# Altium schematic sheet Sheet1.SchDoc
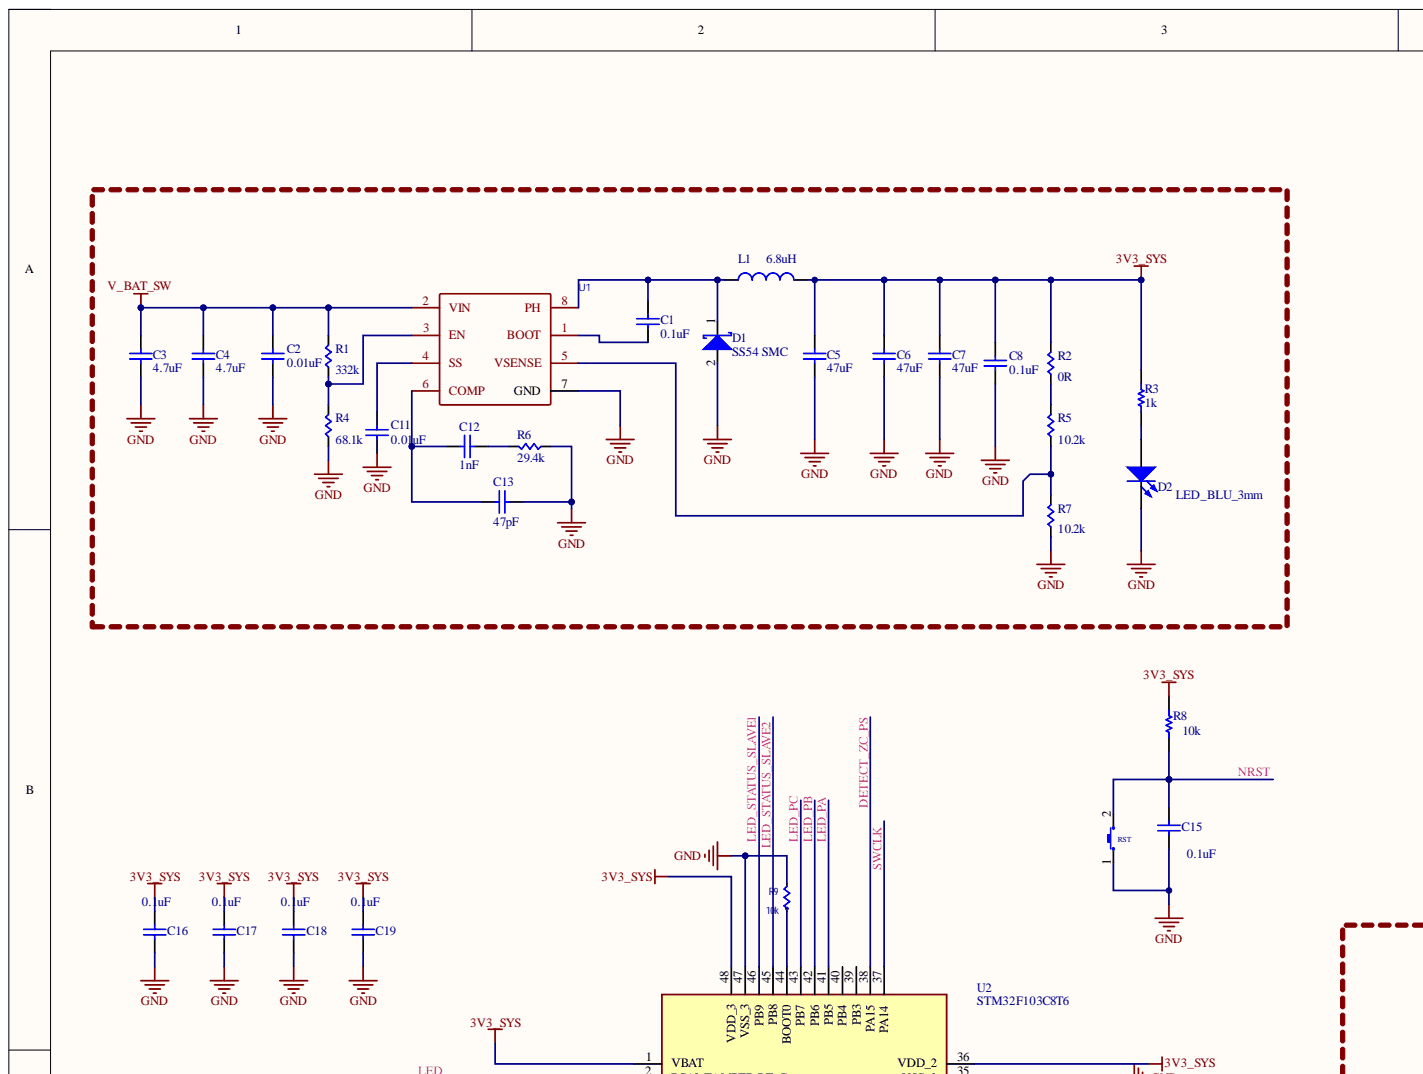
[diagram p0: Sheet1.SchDoc, top left]
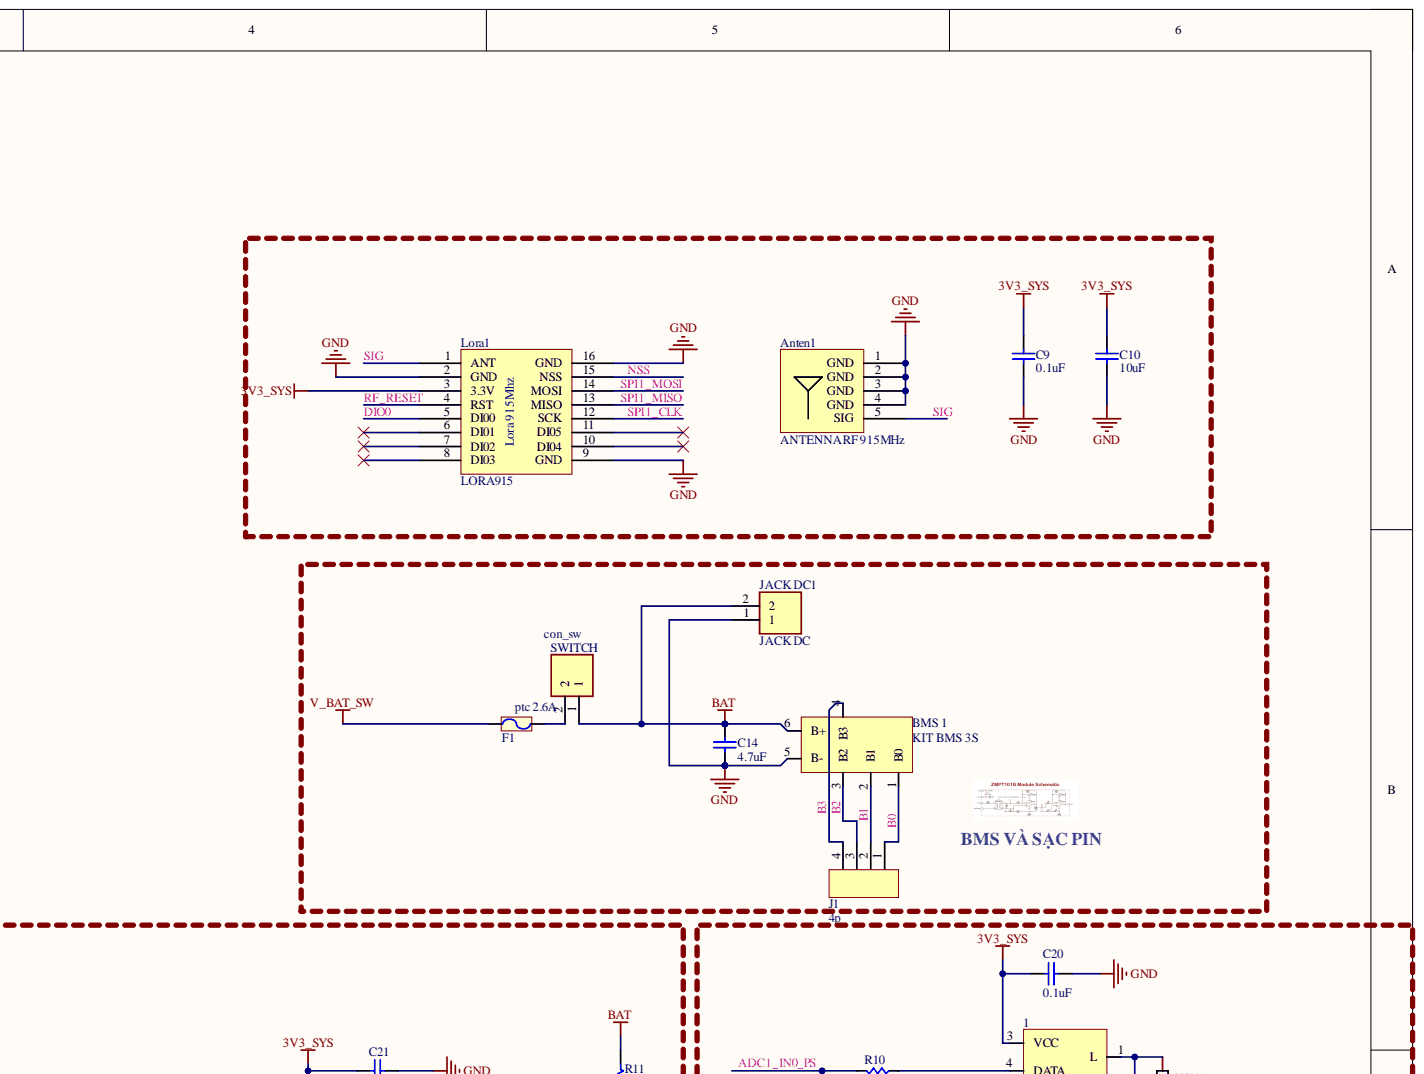
[diagram p1: Sheet1.SchDoc, top right]
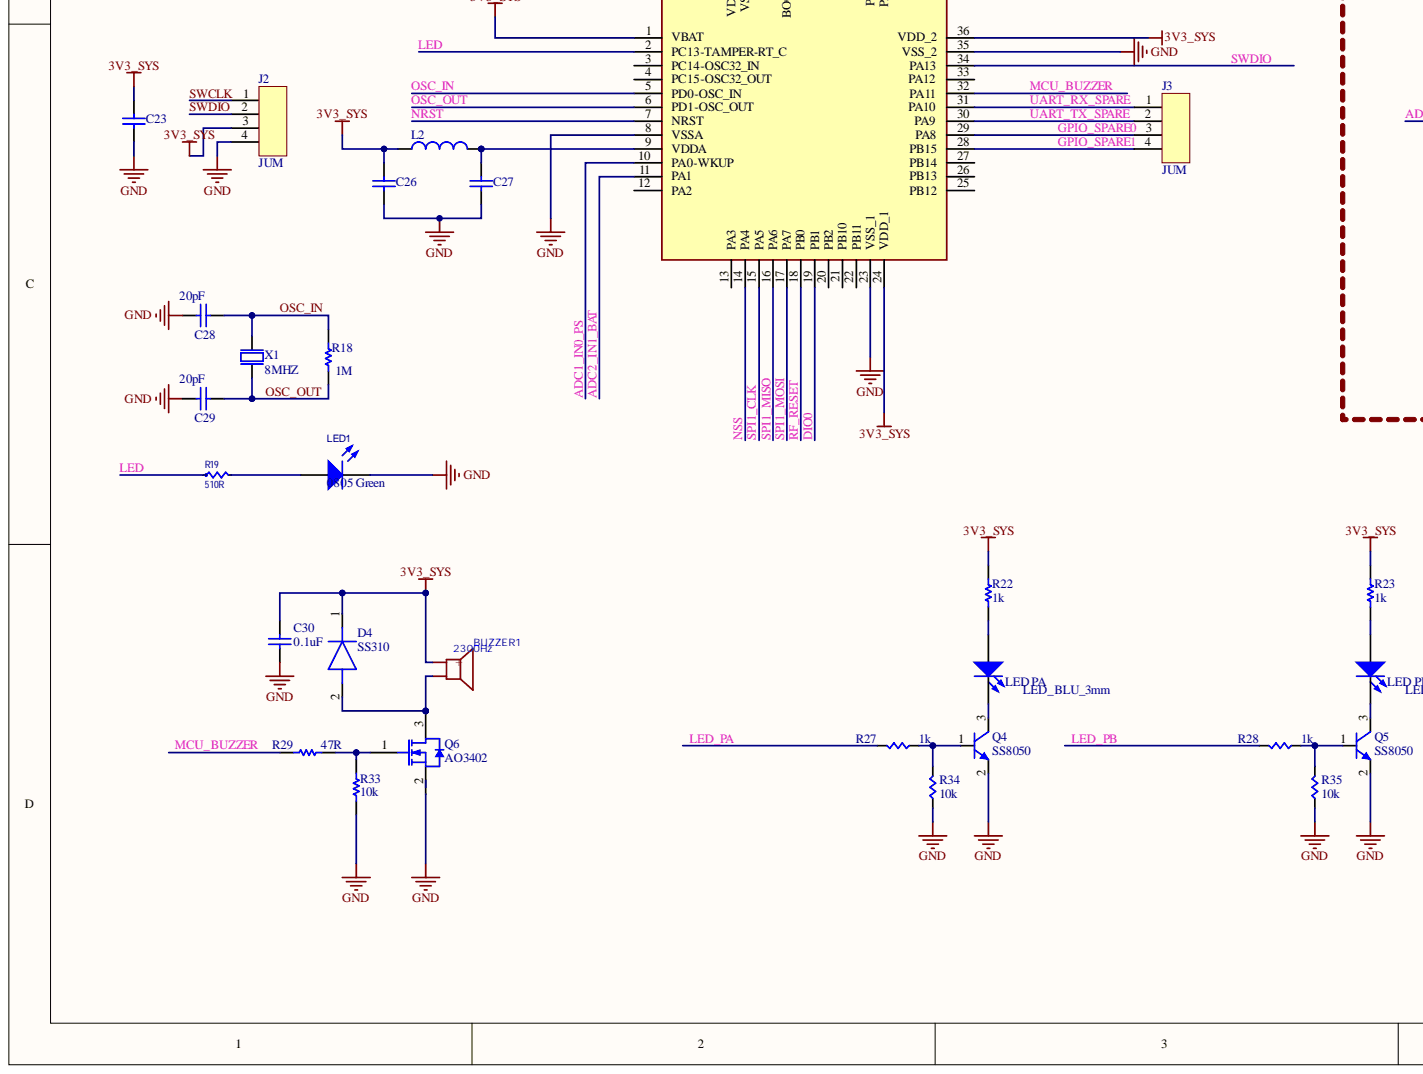
[diagram p2: Sheet1.SchDoc, bottom left]
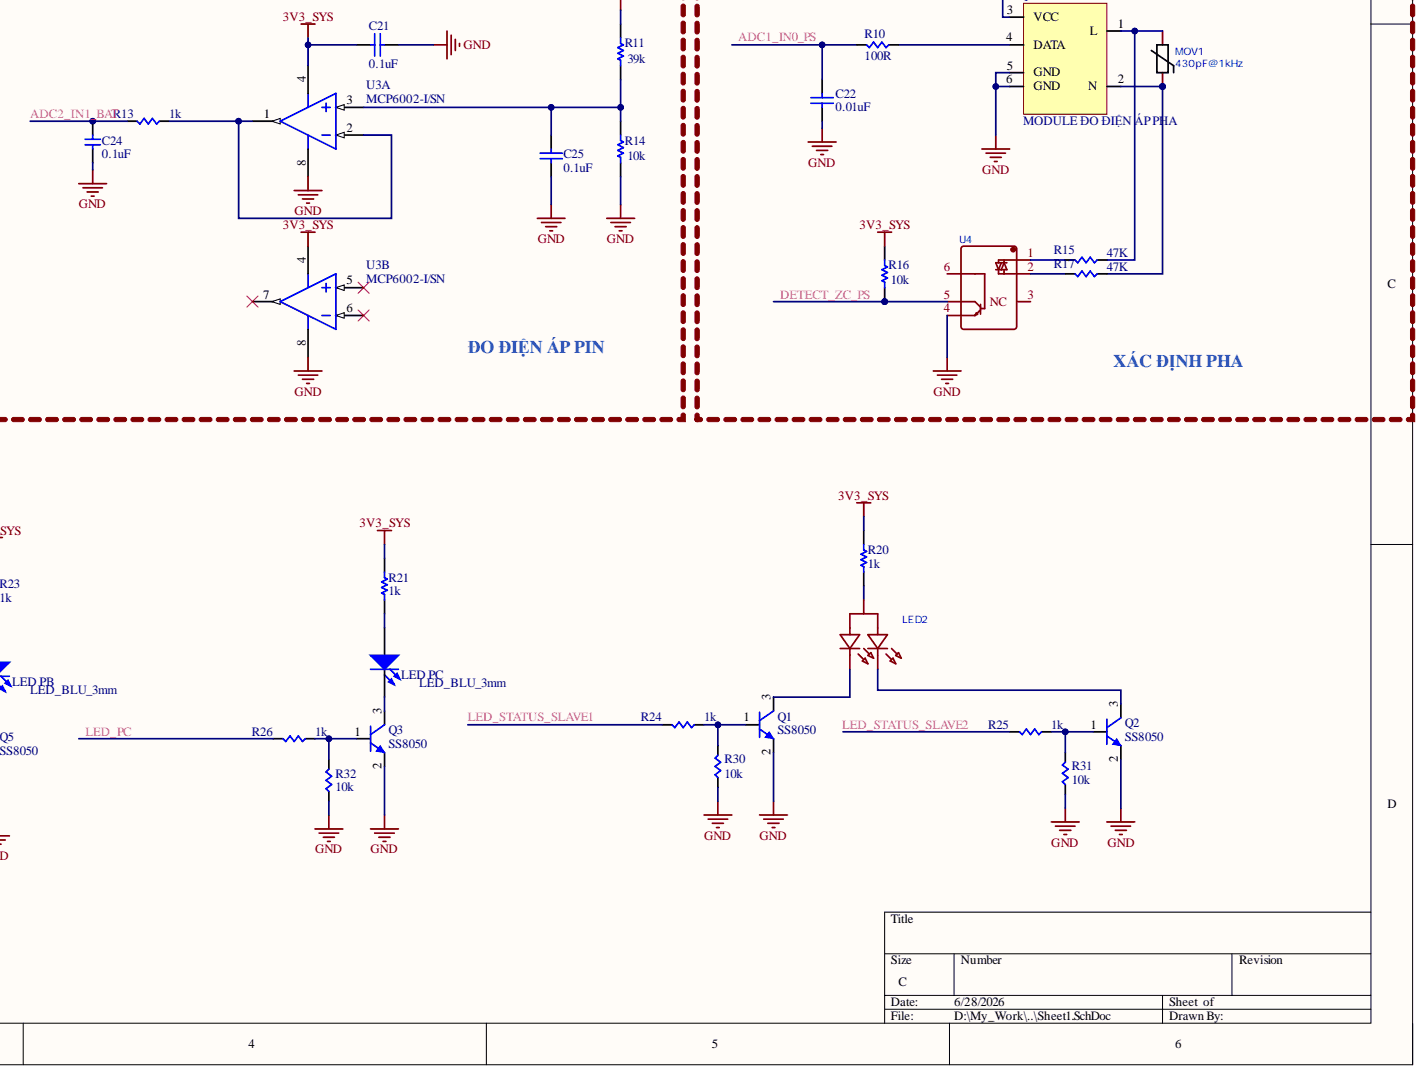
[diagram p3: Sheet1.SchDoc, bottom right]

## Parts
1  MODULE ĐO ĐIỆN ÁP PHA  [KIT_ZMPT101B]
Anten1  ANTENNA RF 915MHz  [ANTEN_SMA]
BMS_1  KIT BMS 3S  [KIT_BMS_3S_20A]
BUZZER1  2300Hz  [BUZZER 9MM]
C1  0.1uF  [CAP_0603]
C2  0.01uF  [CAP_0603]
C3  4.7uF  [CAP_1206]
C4  4.7uF  [CAP_1206]
C5  47uF  [CAP_1206]
C6  47uF  [CAP_1206]
C7  47uF  [CAP_1206]
C8  0.1uF  [CAP_0603]
C9  0.1uF  [CAP_0603]
C10  10uF  [CAP_1206]
C11  0.01uF  [CAP_0603]
C12  1nF  [CAP_0603]
C13  47pF  [CAP_0603]
C14  4.7uF  [CAP_1206]
C15  0.1uF  [CAP_0603]
C16  0.1uF  [CAP_0603]
C17  0.1uF  [CAP_0603]
C18  0.1uF  [CAP_0603]
C19  0.1uF  [CAP_0603]
C20  0.1uF  [CAP_0603]
C21  0.1uF  [CAP_0603]
C22  0.01uF  [CAP_0603]
C23  0.1uF  [CAP_0603]  (value not drawn)
C24  0.1uF  [CAP_0603]
C25  0.1uF  [CAP_0603]
C26  10uF  [CAP_1206]  (value not drawn)
C27  10uF  [CAP_1206]  (value not drawn)
C28  20pF  [CAP_0603]
C29  20pF  [CAP_0603]
C30  0.1uF  [CAP_0603]
D1  SS54 SMC  [SMB]
D2  LED_BLU_3mm  [LED_3mm]
D4  SS310  [SMA (DO-214AC)]
F1  ptc 2.6A  [FUSE_1812]
J1  4p  [CON_1x4p_2.54mm_F_STR]
J2  JUM  [CON_1x4p_2.54_M_straight]
J3  JUM  [CON_1x4p_2.54_M_straight]
JACK_DC1  JACK DC  [CON_1x2P_2.54mm_F_STR_XH]
L1  6.8uH  [IND_6.6x7.3mm]
L2  IND 2.2UH  [RES_0805]  (value not drawn)
LED1  0805 Green  Package: 0805  [LED_0603]
LED2  (no value)  [LED_3P_D3mm]
LED_PA  LED_BLU_3mm  [LED_3mm]
LED_PB  LED_BLU_3mm  [LED_3mm]
LED_PC  LED_BLU_3mm  [LED_3mm]
Lora1  LORA915  [LORA_RA-01H]
MOV1  430pF@1kHz  [MOV_7.5mm]
Q1  SS8050  [SOT-23-3]
Q2  SS8050  [SOT-23-3]
Q3  SS8050  [SOT-23-3]
Q4  SS8050  [SOT-23-3]
Q5  SS8050  [SOT-23-3]
Q6  AO3402  [SOT-23-3]
R1  332k  [RES_0603]
R2  0R  [RES_0603]
R3  1k  [RES_0805]
R4  68.1k  [RES_0603]
R5  10.2k  [RES_0603]
R6  29.4k  [RES_0603]
R7  10.2k  [RES_0603]
R8  10k  [RES_0603]
R9  10k  [RES_0603]
R10  100R  [RES_0603]
R11  39k  [RES_0603]
R13  1k  [RES_0603]
R14  10k  [RES_0603]
R15  47K  [RES_DIP_D4.8xL13mm]
R16  10k  [RES_0603]
R17  47K  [RES_DIP_D4.8xL13mm]
R18  1M  [RES_0603]
R19  510R  [RES_0603]
R20  1k  [RES_0805]
R21  1k  [RES_0805]
R22  1k  [RES_0805]
R23  1k  [RES_0805]
R24  1k  [RES_0603]
R25  1k  [RES_0603]
R26  1k  [RES_0603]
R27  1k  [RES_0603]
R28  1k  [RES_0603]
R29  47R  [RES_0603]
R30  10k  [RES_0603]
R31  10k  [RES_0603]
R32  10k  [RES_0603]
R33  10k  [RES_0603]
R34  10k  [RES_0603]
R35  10k  [RES_0603]
RST  button  [BUTTON_SMD_3625]  (value not drawn)
SWITCH  con_sw  [CON_1x2P_2.54mm_F_STR_XH]
U1  (no value)  [SOIC-8]
U2  STM32F103C8T6  [LQFP-48]
U3  MCP6002-I/SN  [SOIC-SN8_M]  (parts A,B)
U4  (no value)  [PDIP-6]
X1  8MHZ  [Crystal_5032]

## Nets
3V3_SYS: 1.3(VCC) BUZZER1.1 C5.2 C6.2 C7.2 C8.1 C9.2 C10.2 C16.2 C17.2 C18.2 C19.2 C20.2 C21.2 C23.2 C26.2 C30.2 D4.1 J2.3 L1.2 L2.1 Lora1.3(3.3V) R2.2 R3.1 R8.2 R16.1 R20.1 R21.1 R22.1 R23.1 U2.1(VBAT) U2.24(VDD_1) U2.36(VDD_2) U2.48(VDD_3) U3.4
BAT: BMS_1.6(B+) C14.2 JACK_DC1.2 R11.1 SWITCH.1
GND: 1.5(GND) 1.6(GND) Anten1.1(GND) Anten1.2(GND) Anten1.3(GND) Anten1.4(GND) BMS_1.5(B-) C2.2 C3.1 C4.1 C5.1 C6.1 C7.1 C8.2 C9.1 C10.1 C11.2 C13.2 C14.1 C15.1 C16.1 C17.1 C18.1 C19.1 C20.1 C21.1 C22.2 C23.1 C24.2 C25.1 C26.1 C27.1 C28.1 C29.1 C30.1 D1.2 D2.1 J2.4 JACK_DC1.1 LED1.2 Lora1.2(GND) Lora1.9(GND) Lora1.16(GND) Q1.2 Q2.2 Q3.2 Q4.2 Q5.2 Q6.2 R4.1 R6.1 R7.1 R9.2 R14.2 R30.1 R31.1 R32.1 R33.1 R34.1 R35.1 RST.1 U1.7(GND) U2.8(VSSA) U2.23(VSS_1) U2.35(VSS_2) U2.47(VSS_3) U3.8 U4.4
V_BAT_SW: C2.1 C3.2 C4.2 F1.1 R1.2 U1.2(VIN)
ADC1_IN0_PS: C22.1 R10.2 U2.10(PA0-WKUP)
ADC2_IN1_BAT: C24.1 R13.2 U2.11(PA1)
B0: BMS_1.1(B0) J1.1
B1: BMS_1.2(B1) J1.2
B2: BMS_1.3(B2) J1.3
B3: BMS_1.4(B3) J1.4
DETECT_ZC_PS: R16.2 U2.38(PA15) U4.5
DIO0: Lora1.5(DI00) U2.19(PB1)
GPIO_SPARE0: J3.3 U2.29(PA8)
GPIO_SPARE1: J3.4 U2.28(PB15)
LED: R19.1 U2.2(PC13-TAMPER-RT_C)
LED_PA: R27.2 U2.41(PB5)
LED_PB: R28.2 U2.42(PB6)
LED_PC: R26.2 U2.43(PB7)
LED_STATUS_SLAVE1: R24.2 U2.46(PB9)
LED_STATUS_SLAVE2: R25.2 U2.45(PB8)
MCU_BUZZER: R29.2 U2.32(PA11)
NRST: C15.2 R8.1 RST.2 U2.7(NRST)
NSS: Lora1.15(NSS) U2.14(PA4)
OSC_IN: C28.2 R18.1 U2.5(PD0-OSC_IN) X1.1
OSC_OUT: C29.2 R18.2 U2.6(PD1-OSC_OUT) X1.2
RF_RESET: Lora1.4(RST) U2.18(PB0)
SIG: Anten1.5(SIG) Lora1.1(ANT)
SPI1_CLK: Lora1.12(SCK) U2.15(PA5)
SPI1_MISO: Lora1.13(MISO) U2.16(PA6)
SPI1_MOSI: Lora1.14(MOSI) U2.17(PA7)
SWCLK: J2.1 U2.37(PA14)
SWDIO: J2.2 U2.34(PA13)
UART_RX_SPARE: J3.1 U2.31(PA10)
UART_TX_SPARE: J3.2 U2.30(PA9)
Net1_1: 1.1(L) MOV1.2 R15.1
Net1_2: 1.2(N) MOV1.1 R17.1
Net1_4: 1.4(DATA) R10.1
NetBUZZER1_2: BUZZER1.2 D4.2 Q6.3
NetC1_1: C1.1 U1.1(BOOT)
NetC1_2: C1.2 D1.1 L1.1 U1.8(PH)
NetC11_1: C11.1 U1.4(SS)
NetC12_1: C12.1 C13.1 U1.6(COMP)
NetC12_2: C12.2 R6.2
NetC25_2: C25.2 R11.2 R14.1 U3.3
NetC27_2: C27.2 L2.2 U2.9(VDDA)
NetD2_2: D2.2 R3.2
NetF1_2: F1.2 SWITCH.2
NetLED1_1: LED1.1 R19.2
NetLED2_1: LED2.1 Q2.3
NetLED2_2: LED2.2 R20.2
NetLED2_3: LED2.3 Q1.3
NetLED PA_1: LED_PA.1 Q4.3
NetLED PA_2: LED_PA.2 R22.2
NetLED PB_1: LED_PB.1 Q5.3
NetLED PB_2: LED_PB.2 R23.2
NetLED PC_1: LED_PC.1 Q3.3
NetLED PC_2: LED_PC.2 R21.2
NetQ1_1: Q1.1 R24.1 R30.2
NetQ2_1: Q2.1 R25.1 R31.2
NetQ3_1: Q3.1 R26.1 R32.2
NetQ4_1: Q4.1 R27.1 R34.2
NetQ5_1: Q5.1 R28.1 R35.2
NetQ6_1: Q6.1 R29.1 R33.2
NetR1_1: R1.1 R4.2 U1.3(EN)
NetR2_1: R2.1 R5.2
NetR5_1: R5.1 R7.2 U1.5(VSENSE)
NetR9_1: R9.1 U2.44(BOOT0)
NetR13_1: R13.1 U3.1 U3.2
NetR15_2: R15.2 U4.1
NetR17_2: R17.2 U4.2

Not connected: Lora1 6(DI01) 7(DI02) 8(DI03) 10(DI04) 11(DI05); U2 3(PC14-OSC32_IN) 4(PC15-OSC32_OUT) 12(PA2) 13(PA3) 20(PB2) 21(PB10) 22(PB11) 25(PB12) 26(PB13) 27(PB14) 33(PA12) 39(PB3) 40(PB4); U3 5 6 7; U4 3(NC) 6

## Library data of the parts
1 = MODULE?: Component Kind: Standard; Library Name: Schlib1.SchLib
Anten1 = ANTENNA RF 915MHz: https://linhkienchatluong.vn/urlde-anten/de-anten-sma-5-chan-cai-cong-sma-kwe-dai-17mm_sp1918_ct14413.aspx; Manufacturer 1: BAT WIRELESS; Mfr. Part # 1: BWSMA-KWE-Z001; In Process: Yes; Process Type: DIP; VTP Description: CONN RP-SMA JACK R/A 50 OHM PCB; Category: SMA Antenna; Component Kind: Standard; Manufacturer 2: Lian Xin Technology; Mfr. Part # 2: SMA-KWE; NI Mark: Yes; Package: Plugin; Packaging: Bag; Supplier 1: LCSC; Supplier 2: LCSC; Library Name: AP AGV.IntLib
BUZZER1 = TMB12A03: https://linhkienchatluong.vn/urlbuzzer---coi-chip---coi-bao/coi-chip-3v-tmb12a03-12x95mm_sp798_ct8395.aspx; Component Kind: Standard
C1 = Cap-NP-100: 100nF ±10% 50V X7R 0805 Multilayer Ceramic Capacitors MLCC - SMD/SMT RoHS; Manufacturer: YAGEO; Mfr. Part #: CC0805KRX7R9BB104; NI Mark: Yes; Process Type: SMT; Component Kind: Standard; LCSC Part #: C49678; Library Name: Full.SchLib; Package: 0805; Packaging: Tape and Reel; Supplier: LCSC
C2 C5-C8 C11-C13 C22 = Cap-NP-100: Manufacturer: YAGEO; Mfr. Part #: CC0805KRX7R9BB104; NI Mark: Yes; Process Type: SMT; Component Kind: Standard; LCSC Part #: C49678; Library Name: Full.SchLib; Package: 0805; Packaging: Tape and Reel; Supplier: LCSC
C3 C4 C14 = Cap_0.47uF: 50V 4.7uF X5R ±10% 0805 Multilayer Ceramic Capacitors MLCC - SMD/SMT ROHS; Manufacturer: YAGEO; Mfr. Part #: CC0805KKX5R9BB475; NI Mark: Yes; Process Type: SMT; Component Kind: Standard; LCSC Part #: C2897302; Library Name: LIB_SCH_BOOST.schLib; Package: 0805; Packaging: Tape and Reel; Supplier: LCSC
C9 C20 C21 C25 = Cap-NP-100_2: Manufacturer 1: YAGEO; Mfr. Part # 1: CC0805KRX7R9BB104; In Process: Yes; Process Type: SMT; VTP Description: CAP CER 0.1UF 50V X7R 0805; Category: Cap Cereramic; Component Kind: Standard; Manufacturer 2: FH (Guangdong Fenghua Advanced Tech); Mfr. Part # 2: 0805B104K500NT; NI Mark: Yes; Package: 0805; Packaging: Tape and Reel; Supplier 1: LCSC; Supplier 2: LCSC; Value: 0.1uF; Library Name: center board V1.IntLib
C10 = Cap-NP-100_2: Manufacturer 1: FH (Guangdong Fenghua Advanced Tech); Mfr. Part # 1: 0805X106K500NT; In Process: Yes; Process Type: SMT; VTP Description: CAP CER 10UF 50V X5R 0805; Category: Cap Cereramic; Component Kind: Standard; Manufacturer 2: Samsung Electro-Mechanics; Mfr. Part # 2: CL21A106KBYQNNE; NI Mark: Yes; Package: 0805; Packaging: Tape and Reel; Supplier 1: LCSC; Supplier 2: LCSC; Value: 10uF; Library Name: center board V1.IntLib
C15-C19 C23 = Cap-104: 100nF ±10% 50V X7R 0805 Multilayer Ceramic Capacitors MLCC - SMD/SMT RoHS; Manufacturer 1: YAGEO; Mfr. Part # 1: CC0805KRX7R9BB104; NI Mark: Yes; Process Type: SM; VTP-Description: 50V 100nF X7R ±10% 0805 Multilayer Ceramic Capacitors MLCC - SMD/SMT ROHS; Component Kind: Standard; Library Name: PowerSupply Robot V1.SchLib; Manufacturer 2: FH (Guangdong Fenghua Advanced Tech); Mfr. Part # 2: 0805B104K500NT; Package: 0805; Packaging: Tape & Reel; Supplier 1: LCSC; Supplier 2: LCSC
C24 = GRM21BR61A226ME51L: 100V 100nF X7R ±10% 0603 Ceramic Capacitors RoHS; Manufacturer: YAGEO; Mfr. Part #: CC0603KRX7R0BB104; In Process: Yes; Process Type: SMT; Component Kind: Standard; Database Table Name: Capacitor; DigiKey Part Number: 311-1523-2-ND; LCSC Part #: C113803; Library Name: Component Library.DbLib; Manufacturer 1: YAGEO; Mfr. Part # 1: CC0603KRX7R0BB104; Package: 0603; Packaging: Tape and Reel; Supplier: LCSC; Value: 0.1uF; VIND_Description: 100V 100nF X7R ±10% 0603 Ceramic Capacitors RoHS
C26 C27 = Cap-10uF: 10uF ±10% 35V X5R 0805 Multilayer Ceramic Capacitors MLCC - SMD/SMT RoHS; Manufacturer 1: YAGEO; Mfr. Part # 1: CC0805KKX5R8BB106; NI Mark: Yes; Process Type: SM; VTP-Description: 25V 10uF X5R ±10% 0805 Multilayer Ceramic Capacitors MLCC - SMD/SMT ROHS; Component Kind: Standard; Library Name: PowerSupply Robot V1.SchLib; Manufacturer 2: FH (Guangdong Fenghua Advanced Tech); Mfr. Part # 2: 0805X106K250NT; Package: 0805; Packaging: Tape & Reel; Supplier 1: LCSC; Supplier 2: LCSC
C28 C29 = Cap-NP-100: 20pF ±10% 50V X7R 0805 Multilayer Ceramic Capacitors MLCC - SMD/SMT RoHS; Manufacturer 1: YAGEO; Mfr. Part # 1: CC0805JRNPO9BN200; NI Mark: Yes; Process Type: SM; VTP-Description: 50V 20pF NP0 ±5% 0805 Multilayer Ceramic Capacitors MLCC - SMD/SMT ROHS; Component Kind: Standard; Library Name: Full.SchLib; Manufacturer 2: FH (Guangdong Fenghua Advanced Tech); Mfr. Part # 2: 0805CG200J500NT; Package: 0805; Packaging: Tape & Reel; Supplier 1: LCSC; Supplier 2: LCSC
C30 = Cap-NP-100: 100V 100nF X7R ±10% 0603 Ceramic Capacitors RoHS; Manufacturer: YAGEO; Mfr. Part #: CC0603KRX7R0BB104; In Process: Yes; Process Type: SMT; Component Kind: Standard; DigiKey Part Number: 311-1523-2-ND; LCSC Part #: C113803; Library Name: Full.SchLib; Manufacturer 1: YAGEO; Mfr. Part # 1: CC0603KRX7R0BB104; Package: 0603; Packaging: Tape and Reel; Supplier: LCSC; Supplier 1: Digikey; Value: 0.1uF; VIND_Description: 100V 100nF X7R ±10% 0603 Ceramic Capacitors RoHS
D1 = diode SS14_1: https://www.linhkienchatluong.vn/urldiode-schottky/1n5824-ss54-smc-diode-schottky-5a-40v-chinh-hang-mic_sp571_ct1265.aspx; Component Kind: Standard; Library Name: PowerSupply Robot V1.SchLib
D2 LED_PA LED_PB LED_PC = LED_RED_SMD_0805: https://linhkienchatluong.vn/urlled-don-3mm---f3/led-3mm-xanh-duong-chan-dai-27mm-10-chiec_sp1975_ct1886.aspx; Component Kind: Standard; Library Name: center board V1.IntLib
D4 = 1N4148W-7-F: https://linhkienchatluong.vn/urldiode-schottky/sr3100-ss310-sma-diode-schottky-3a-100v-chinh-hang-mic-10-chiec_sp571_ct11113.aspx; Manufacturer: DIODES; In Process: Yes; Process Type: SMT; VIND_Description: 2A 4ns 100V Independent 1.25V@150mA 300mA SOD-123 Single Diodes RoHS; Component Kind: Standard; LCSC Part #: C83528; Library Name: SamacSys.SchLib; Mfr. Part #: 1N4148W-7-F; Package: SOD-123; Packaging: Tape and Reel; Supplier: LCSC; Value: 1N4148W-7-F
F1 = Fuse: https://linhkienchatluong.vn/urlcau-chi-tu-phuc-hoi-ptc/cau-chi-tu-phuc-hoi-1812-26a-6v-smd-chinh-hang-jdtfuse_sp845_ct1259.aspx; Manufacturer: Littelfuse; Mfr. Part #: 0466005.NRHF; NI Mark: Yes; Process Type: SMT; Component Kind: Standard; LCSC Part #: C57525; Library Name: ServoDrive.IntLib; Package: 1206; Packaging: Tape and Reel; Supplier: LCSC
J1 = CON HDR 4 PIN 1X4 2.5MM XHB-4A: https://linhkienchatluong.vn/urlheader-xh254-chan-duc-thang/header-duc-4p-xh254-4p-chan-thang-10-chiec_sp1781_ct1713.aspx; Manufacturer 1: HCTL; Mfr. Part # 1: HC-XHB-4AW; NI Mark: Yes; Process Type: MA; VTP-Description: 1x4P 4P 1 Tin 2.5mm 4 -25℃~+85℃ Brass 3A Right-Angle Push-Pull,P=2.5mm Wire To Board Connector ROHS; Component Kind: Standard; Library Name: PowerSupply Robot V1.SchLib; Manufacturer 2: BOOMELE(Boom Precision Elec); Mfr. Part # 2: XH-4AW; Package: Push-Pull,P=2.5mm; Packaging: Bag-packed; Supplier 1: LCSC; Supplier 2: LCSC
J2 J3 = CON HDR 4 PIN 1X4 2.5MM XHB-4A: 1x4P 4P XH 1 2.5mm 4 Phosphor bronze Right-Angle Push-Pull,P=2.5mm Wire To Board Connector ROHS; Manufacturer 1: HCTL; Mfr. Part # 1: HC-XHB-4AW; NI Mark: Yes; Process Type: MA; VTP-Description: 1x4P 4P 1 Tin 2.5mm 4 -25℃~+85℃ Brass 3A Right-Angle Push-Pull,P=2.5mm Wire To Board Connector ROHS; Component Kind: Standard; Library Name: PowerSupply Robot V1.SchLib; Manufacturer 2: BOOMELE(Boom Precision Elec); Mfr. Part # 2: XH-4AW; Package: Push-Pull,P=2.5mm; Packaging: Bag-packed; Supplier 1: LCSC; Supplier 2: LCSC
JACK_DC1 SWITCH = Header 2P Plug 90 5.08: https://linhkienchatluong.vn/urlheader-xh254-chan-duc-thang/header-duc-2p-xh254-2p-chan-thang-10c_sp1781_ct1711.aspx; Component Kind: Standard; Library Name: Integrated_Library_EVT.IntLib
L1 = inductor 2.2uF: https://linhkienchatluong.vn/urlcuon-cam-dan-cd104-10x10x4mm/cuon-cam-dan-cd104-6r8-68uh-10x10x4mm_sp1635_ct3517.aspx; Manufacturer: cjiang; Component Kind: Standard; LCSC Part #: C167222; Library Name: PowerSupply Robot V1.SchLib; Supplier: LCSC
L2 = inductor 2.2uF_1: 10uH ±20% 800mA 435mΩ SMD,2.5x2.0x1.0mm Inductors (SMD) RoHS; Manufacturer 1: APV; Mfr. Part # 1: APSWPA252012S100MTF; NI Mark: Yes; Process Type: SM; VTP-Description: 620mA 10uH 磁胶屏蔽绕线电感 ±20% 790mA SMD,2.5x2mm Power Inductors ROHS; Component Kind: Standard; Library Name: PowerSupply Robot V1.SchLib; Manufacturer 2: Cybermax; Mfr. Part # 2: CMLW252010S100MST; Package: SMD,2.5x2mm; Packaging: Tape & Reel; Supplier 1: LCSC; Supplier 2: LCSC
LED1 = LED0: LED SMARTLED GREEN 570NM 0603; Manufacturer 1: XINGLIGHT; Mfr. Part # 1: XL-2012SURC; NI Mark: Yes; Process Type: SM; VTP-Description: 20mA 230mcd Colorless transparence -20℃~+85℃ Positive post 615nm~630nm Red 120° 55mW 2.3V 0805 Light Emitting Diodes (LED) ROHS; Component Kind: Standard; Library Name: Miscellaneous Devices.IntLib; Manufacturer 2: Everlight Elec; Mfr. Part # 2: 17-21/R6C-AQ2R2B/4T; Packaging: Tape & Reel; Simulation: LED0; Supplier 1: LCSC; Supplier 2: LCSC
LED2 = TZ-LAMP03RG4HD-A: https://linhkienchatluong.vn/urlled-don-3mm---f3/led-3mm-2-mau-do-xanh-la-tinh-khiet-a-chung-chan-dai-27mm-10c_sp1975_ct8579.aspx; Component Kind: Standard
Lora1 = LORA915: Manufacturer 1: Ai-Thinker; Mfr. Part # 1: RA-01H; In Process: Yes; Process Type: SMT; VTP Description: MODULE RF TXRX RA-01H SMD; Category: Module Lora 915MHz; Component Kind: Standard; Library Name: Lora915.IntLib; NI Mark: Yes; Package: SMD-16; Packaging: Tape and Reel; Supplier 1: LCSC
MOV1 = MOV14D471K206RV080: Component Kind: Standard
Q1-Q5 = npn_smd: https://linhkienchatluong.vn/urltransistor/ss8050-y1-npn-transistor-15a-25v-sot-23-chinh-hang-jcet-10-chiec_sp787_ct13107.aspx; Manufacturer: Guangdong Hottech; NI Mark: Yes; Process Type: SMT; Component Kind: Standard; LCSC Part #: C181160; Library Name: Power Robot V1.SCHLIB; Mfr. Part #: SS8050; Package: SOT23-3; Packaging: Tape & Reel; Supplier: LCSC
Q6 = MOSFET_N_D3G1S2: https://linhkienchatluong.vn/urlmosfet/ao3402-r2-n-mosfet-4a-30v-sot-23-chinh-hang-jcet-10-chiec_sp589_ct14414.aspx; Manufacturer: UMW; Mfr. Part #: AO3400A; In Process: Yes; Process Type: SMT; Value: AO3400A; VIND_Description: 30V 5.8A 17mΩ@10V 1.4W One N-channel SOT-23 Single FETs, MOSFETs RoHS; Component Kind: Standard; DigiKey Part Number: 4518-AO3400ATR-ND; LCSC Part #: C347475; Library Name: SamacSys.SchLib; Manufacturer 1: UMW; Mfr. Part # 1: AO3400A; Package: SOT-23; Packaging: Tape and Reel; Supplier: LCSC; Supplier 1: Digikey
R1 R2 R4-R7 R10 R15 R17 = RES 10K OHM 1% 1/10W 0603: Manufacturer: YAGEO; Mfr. Part #: RC0805FR-0710KL; Component Kind: Standard; LCSC Part #: C84376; Library Name: TEMPLATE_SCHLIB_ V5_26092022.SCHLIB
R3 R20-R23 = Res0.3_2: Manufacturer 1: YAGEO; Mfr. Part # 1: RC0805FR-071KL; In Process: Yes; Process Type: SMT; VTP Description: RES 1K OHM 1% 1/8W 0805; Category: Resistor; Component Kind: Standard; Manufacturer 2: FH(Guangdong Fenghua Advanced Tech); Mfr. Part # 2: RS-05K1001FT; NI Mark: Yes; Package: 0805; Packaging: Tape and Reel; Supplier 1: LCSC; Supplier 2: LCSC; Value: 1k; Library Name: center board V1.IntLib
R8 = Res10k_Ohm_1: 1/8W Thick Film Resistors 150V ±100ppm/℃ ±1% 10kΩ 0805 Chip Resistor - Surface Mount ROHS; Manufacturer 1: YAGEO; Mfr. Part # 1: RC0805FR-0710KL; NI Mark: Yes; Process Type: SM; VTP-Description: 125mW Thick Film Resistors 150V ±100ppm/℃ ±1% 10kΩ 0805 Chip Resistor - Surface Mount ROHS; Component Kind: Standard; Library Name: PowerSupply Robot V1.SchLib; Manufacturer 2: FH (Guangdong Fenghua Advanced Tech); Mfr. Part # 2: RS-05K1002FT; Package: 0805; Packaging: Tape & Reel; Supplier 1: LCSC; Supplier 2: LCSC
R9 = P-RES-0603-510R 1%: Res Thick Film 0805 10K Ohm 1% 0.125W(1/8W) ±100ppm/C Pad SMD Automotive T/R; Manufacturer 1: YAGEO; Mfr. Part # 1: RC0805FR-0710KL; NI Mark: Yes; Process Type: SM; VTP-Description: 125mW Thick Film Resistors 150V ±100ppm/℃ ±1% 10kΩ 0805 Chip Resistor - Surface Mount ROHS; Component Kind: Standard; Manufacturer 2: FH (Guangdong Fenghua Advanced Tech); Mfr. Part # 2: RS-05K1002FT; Package: 0805; Packaging: Tape & Reel; Supplier 1: LCSC; Supplier 2: LCSC; Library Name: Combine_1.SCHLIB
R11 R14 R16 = Res0.3_2: Component Kind: Standard; Library Name: center board V1.IntLib
R13 = RES 10K OHM 1% 1/10W 0603: 100mW 470Ω 75V ±100ppm/℃ Thick Film Resistor ±1% 0603 Chip Resistor - Surface Mount RoHS; Manufacturer: YAGEO; Mfr. Part #: RC0603FR-071KL; In Process: Yes; Process Type: SMT; VIND_Description: 100mW 1kΩ 75V ±100ppm/℃ Thick Film Resistor ±1% 0603 Chip Resistor - Surface Mount RoHS; Component Kind: Standard; LCSC Part #: C22548; Package: 0603; Packaging: Tape and Reel; Supplier: LCSC; Value: 1k; Library Name: TEMPLATE_SCHLIB_ V5_26092022.SCHLIB
R18 = Res0.3: 1W ±100ppm/℃ ±1% 1MΩ 0805 Chip Resistor - Surface Mount ROHS; Manufacturer 1: YAGEO; Mfr. Part # 1: RC0805FR-071ML; NI Mark: Yes; Process Type: SM; VTP-Description: 125mW Thick Film Resistors 150V ±100ppm/℃ ±1% 1MΩ 0805 Chip Resistor - Surface Mount ROHS; Component Kind: Standard; Library Name: Full.SchLib; Manufacturer 2: FH (Guangdong Fenghua Advanced Tech); Mfr. Part # 2: RS-05K1004FT; Package: 0805; Packaging: Tape & Reel; PCB3D: .; Supplier 1: LCSC; Supplier 2: LCSC
R19 = P-RES-0603-510R 1%: RES SMD 510 OHM 1% 1/10W 0603; Manufacturer 1: YAGEO; Mfr. Part # 1: RC0805FR-07510RL; NI Mark: Yes; Process Type: SM; VTP-Description: 125mW Thick Film Resistors 150V ±100ppm/℃ ±1% 510Ω 0805 Chip Resistor - Surface Mount ROHS; Component Kind: Standard; Manufacturer 2: FH (Guangdong Fenghua Advanced Tech); Mfr. Part # 2: RS-05K5100FT; Package: 0805; Packaging: Tape & Reel; Supplier 1: LCSC; Supplier 2: LCSC; Library Name: Combine_1.SCHLIB
R24-R28 = RES 10K OHM 1% 1/10W 0603: 125mW Thick Film Resistors 150V ±100ppm/℃ ±1% 1kΩ 0805 Chip Resistor - Surface Mount ROHS; Manufacturer: YAGEO; Mfr. Part #: RC0805FR-071KL; NI Mark: Yes; Process Type: SMT; Component Kind: Standard; LCSC Part #: C95781; Package: 0805; Packaging: Tape & Reel; Supplier: LCSC; Library Name: TEMPLATE_SCHLIB_ V5_26092022.SCHLIB
R29 = Res0.3: 100mW 47Ω 75V ±100ppm/℃ Thick Film Resistor ±1% 0603 Chip Resistor - Surface Mount RoHS; Manufacturer: YAGEO; Mfr. Part #: RC0603FR-0747RL; In Process: Yes; Process Type: SMT; Component Kind: Standard; DigiKey Part Number: 311-47.0HRTR-ND; LCSC Part #: C114623; Library Name: Full.SchLib; Manufacturer 1: YAGEO; Mfr. Part # 1: RC0603FR-0747RL; Package: 0603; Packaging: Tape and Reel; Supplier: LCSC; Supplier 1: Digikey; Value: 47R; VIND_Description: 100mW 47Ω 75V ±100ppm/℃ Thick Film Resistor ±1% 0603 Chip Resistor - Surface Mount RoHS
R30-R32 R34 R35 = RES 10K OHM 1% 1/10W 0603: 1/8W Thick Film Resistors 150V ±100ppm/℃ ±1% 10kΩ 0805 Chip Resistor - Surface Mount ROHS; Manufacturer: YAGEO; Mfr. Part #: RC0805FR-0710KL; NI Mark: Yes; Process Type: SMT; Component Kind: Standard; LCSC Part #: C84376; Package: 0805; Packaging: Tape and Reel; Supplier: LCSC; Library Name: TEMPLATE_SCHLIB_ V5_26092022.SCHLIB
R33 = Res0.3: 100mW 10kΩ 75V ±100ppm/℃ Thick Film Resistor ±1% 0603 Chip Resistor - Surface Mount RoHS; Manufacturer: YAGEO; Mfr. Part #: RC0603FR-0710KL; In Process: Yes; Process Type: SMT; Component Kind: Standard; DigiKey Part Number: 311-10.0KHRTR-ND; LCSC Part #: C98220; Library Name: Full.SchLib; Manufacturer 1: YAGEO; Mfr. Part # 1: RC0603FR-0710KL; Package: 0603; Packaging: Tape and Reel; Supplier: LCSC; Supplier 1: Digikey; Value: 10k; VIND_Description: 100mW 10kΩ 75V ±100ppm/℃ Thick Film Resistor ±1% 0603 Chip Resistor - Surface Mount RoHS
RST = button: https://linhkienchatluong.vn/urlnut-nhan-sieu-nho/nut-nhan-2-chan-dan-3x6x25mm-loai-tot-dong-day-10-chiec_sp849_ct1800.aspx; Manufacturer: hanxia; Mfr. Part #: HX TS253015A2P 160gf; Component Kind: Standard; LCSC Part #: C25168826; Library Name: Schlib1.SchLib; Package: SMD,3x2.5mm; Supplier: LCSC
U1 = TPS54331DR: https://linhkienchatluong.vn/urlic-ha-ap---buck/tps54331dr-sop-8-ic-dieu-chinh-ap-dc-chinh-hang-ti_sp1669_ct2270.aspx; Component Kind: Standard
U2 = IC_STM32F103C8T6: https://linhkienchatluong.vn/urlstm/stm32f103c8t6-lqfp48-chinh-hang-st_sp564_ct750.aspx; Manufacturer 1: STMicroelectronics; NI Mark: Yes; Process Type: SM; VTP-Description: 64KB 2V~3.6V ARM-M3 20KB 72MHz FLASH 37 LQFP-48(7x7) Microcontroller Units (MCUs/MPUs/SOCs) ROHS; Component Kind: Standard; Library Name: PowerSupply Robot V1.SchLib; Manufacturer 2: STMicroelectronics; Mfr. Part # 1: STM32F103C8T6; Mfr. Part # 2: STM32F103C8T6TR; Package: LQFP-48(7x7); Packaging: Tray; Supplier 1: LCSC; Supplier 2: LCSC
U3 = CMP-0187-00365-3: https://linhkienchatluong.vn/urlic-opam/mcp6002-isn-sop-8-chinh-hang-microchip_sp574_ct663.aspx; Amplifier Type: GENERAL PURPOSE; Bandwidth: 1MHz; Common Mode Rejection Ratio: 60dB; Input Bias Current: 1pA; Input Offset Voltage (Vos): 4.5mV; Max Supply Voltage: 6V; Min Supply Voltage: 1.8V; Nominal Supply Current: 100uA; Number of Amplifiers: 2; Operating Supply Voltage: 5.5V; Output Current: 23mA; Power Supply Rejection Ratio (PSRR): 86dB; Slew Rate: 0.6V/µs; Voltage Gain: 112dB; Case/Package: SOIC; Component Kind: Standard; Gain Bandwidth Product: 1MHz; Height: 1.25mm; Input Offset Voltage-Max: 4.5mV; Lead Free: Lead Free; Length: 4.9mm; Library Name: Altium Content Vault; Max Operating Temperature: 85°C; Min Operating Temperature: -40°C; Mount: Surface Mount; Number of Channels: 2; Number of Circuits: 2; Number of Elements: 2; Number of Pins: 8; Package Quantity: 100; Packaging: Rail/Tube; Radiation Hardening: No; REACH SVHC: No SVHC; RoHS Compliant: Yes; Slew Rate-Nom: 0.6V/µs; Supply Current: 100uA; Technology: CMOS; Unity Gain Bandwidth Product: 1MHz; Width: 3.9mm
U4 = H11AA1M: Component Kind: Standard
X1 = XTAL_SMD2012_32.768kHz_9pF_Golledge: https://linhkienchatluong.vn/urlcac-loai-thach-anh-khac/thach-anh-8mhz-5032-5x32mm-smd-2p_sp615_ct1170.aspx; Database Table Name: Parts$; Component Kind: Standard; Library Name: AltiumLib.DbLib
BMS_1 = KIT BMS 3S: Component Kind: Standard; Library Name: Schlib1.SchLib
